# Altium schematic sheet top.SchDoc
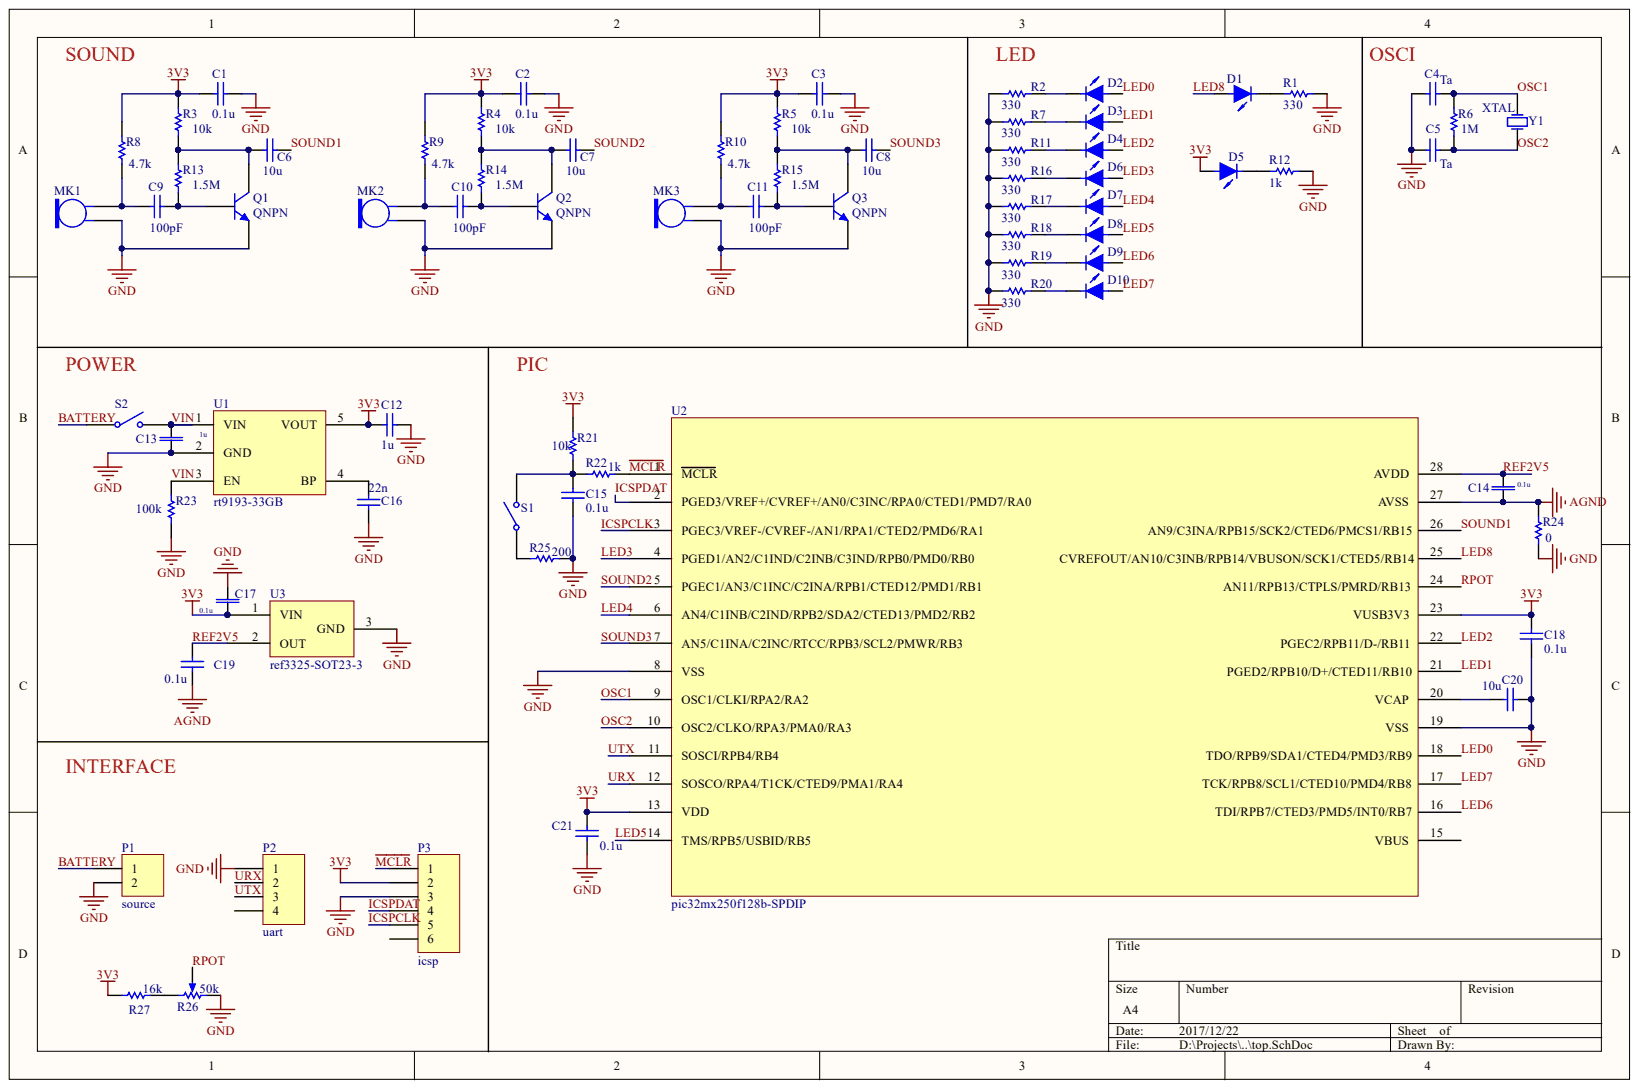
[diagram p0: top.SchDoc]

## Parts
C1  value 0.1u  [CAPC1608N]  (hidden comment: Cap)
C2  value 0.1u  [CAPC1608N]  (hidden comment: Cap)
C3  value 0.1u  [CAPC1608N]  (hidden comment: Cap)
C4  Ta  [CAPC1608N]
C5  Ta  [CAPC1608N]
C6  value 10u  [CAPC1608N]  (hidden comment: Cap)
C7  value 10u  [CAPC1608N]  (hidden comment: Cap)
C8  value 10u  [CAPC1608N]  (hidden comment: Cap)
C9  value 100pF  [CAPC1608N]  (hidden comment: Cap)
C10  value 100pF  [CAPC1608N]  (hidden comment: Cap)
C11  value 100pF  [CAPC1608N]  (hidden comment: Cap)
C12  value 1u  [CAPC1608N]  (hidden comment: Cap)
C13  value 1u  [CAPC1608N]  (hidden comment: Cap)
C14  value 0.1u  [CAPC1608N]  (hidden comment: Cap)
C15  value 0.1u  [CAPC1608N]  (hidden comment: Cap)
C16  value 22n  [CAPC1608N]  (hidden comment: Cap)
C17  value 0.1u  [CAPC1608N]  (hidden comment: Cap)
C18  value 0.1u  [CAPC1608N]  (hidden comment: Cap)
C19  value 0.1u  [CAPC1608N]  (hidden comment: Cap)
C20  Cap  [CAPC1608N]  (value not drawn)
C21  value 0.1u  [CAPC1608N]  (hidden comment: Cap)
D1  LED2  [CD1608-0603]  (value not drawn)
D2  LED2  [CD1608-0603]  (value not drawn)
D3  LED2  [CD1608-0603]
D4  LED2  [CD1608-0603]
D5  LED2  [CD1608-0603]  (value not drawn)
D6  LED2  [CD1608-0603]
D7  LED2  [CD1608-0603]  (value not drawn)
D8  LED2  [CD1608-0603]  (value not drawn)
D9  LED2  [CD1608-0603]  (value not drawn)
D10  LED2  [CD1608-0603]  (value not drawn)
MK1  Mic2  [MK]  (value not drawn)
MK2  Mic2  [MK]  (value not drawn)
MK3  Mic2  [MK]  (value not drawn)
P1  source  [HDR1X2]
P2  uart  [HDR1X4]
P3  icsp  [HDR1X6]
Q1  QNPN  [SOT-23B_N]
Q2  QNPN  [SOT-23B_N]
Q3  QNPN  [SOT-23B_N]
R1  value 330  [RESC1608N]  (hidden comment: Res1)
R2  value 330  [RESC1608N]  (hidden comment: Res1)
R3  value 10k  [RESC1608N]  (hidden comment: Res1)
R4  value 10k  [RESC1608N]  (hidden comment: Res1)
R5  value 10k  [RESC1608N]  (hidden comment: Res1)
R6  value 1M  [RESC1608N]  (hidden comment: Res1)
R7  value 330  [RESC1608N]  (hidden comment: Res1)
R8  value 4.7k  [RESC1608N]  (hidden comment: Res1)
R9  value 4.7k  [RESC1608N]  (hidden comment: Res1)
R10  value 4.7k  [RESC1608N]  (hidden comment: Res1)
R11  value 330  [RESC1608N]  (hidden comment: Res1)
R12  value 1k  [RESC1608N]  (hidden comment: Res1)
R13  value 1.5M  [RESC1608N]  (hidden comment: Res1)
R14  value 1.5M  [RESC1608N]  (hidden comment: Res1)
R15  value 1.5M  [RESC1608N]  (hidden comment: Res1)
R16  value 330  [RESC1608N]  (hidden comment: Res1)
R17  value 330  [RESC1608N]  (hidden comment: Res1)
R18  value 330  [RESC1608N]  (hidden comment: Res1)
R19  value 330  [RESC1608N]  (hidden comment: Res1)
R20  value 330  [RESC1608N]  (hidden comment: Res1)
R21  value 10k  [RESC1608N]  (hidden comment: Res1)
R22  value 1k  [RESC1608N]  (hidden comment: Res1)
R23  value 100k  [RESC1608N]  (hidden comment: Res1)
R24  value 0  [RESC1608N]  (hidden comment: Res1)
R25  value 200  [RESC1608N]  (hidden comment: Res1)
R26  value 50k  [RPOT]  (hidden comment: RPot)
R27  value 16k  [RESC1608N]  (hidden comment: Res1)
S1  SW-SPST  [sw]  (value not drawn)
S2  SW-SPST  [PIN3]  (value not drawn)
U1  rt9193-33GB  [SOT23-5_N]
U2  pic32mx250f128b-SPDIP  [DIP-28]
U3  ref3325-SOT23-3  [SOT23-3]
Y1  XTAL  [XTAL]

## Nets
3V3: C1.2 C2.2 C3.2 C12.2 C17.2 C18.1 C21.1 D5.1 P3.2 R3.2 R4.2 R5.2 R8.1 R9.1 R10.1 R21.2 R27.2 U1.5(VOUT) U2.13(VDD) U2.23(VUSB3V3) U3.1
AGND: C14.2 C19.1 R24.1 U2.27(AVSS)
GND: C1.1 C2.1 C3.1 C4.2 C5.2 C12.1 C13.2 C15.2 C16.1 C17.1 C18.2 C20.1 C21.2 MK1.1 MK2.1 MK3.1 P1.2 P2.1 P3.3 Q1.3 Q2.3 Q3.3 R1.1 R2.1 R7.1 R11.1 R12.1 R16.1 R17.1 R18.1 R19.1 R20.1 R23.1 R24.2 R25.1 R26.1 U1.2(GND) U2.8(VSS) U2.19(VSS) U3.3
BATTERY: P1.1 S2.1
ICSPCLK: P3.5 U2.3(PGEC3/VREF-/CVREF-/AN1/RPA1/CTED2/PMD6/RA1)
ICSPDAT: P3.4 U2.2(PGED3/VREF+/CVREF+/AN0/C3INC/RPA0/CTED1/PMD7/RA0)
LED0: D2.1 U2.18(TDO/RPB9/SDA1/CTED4/PMD3/RB9)
LED1: D3.1 U2.21(PGED2/RPB10/D+/CTED11/RB10)
LED2: D4.1 U2.22(PGEC2/RPB11/D-/RB11)
LED3: D6.1 U2.4(PGED1/AN2/C1IND/C2INB/C3IND/RPB0/PMD0/RB0)
LED4: D7.1 U2.6(AN4/C1INB/C2IND/RPB2/SDA2/CTED13/PMD2/RB2)
LED5: D8.1 U2.14(TMS/RPB5/USBID/RB5)
LED6: D9.1 U2.16(TDI/RPB7/CTED3/PMD5/INT0/RB7)
LED7: D10.1 U2.17(TCK/RPB8/SCL1/CTED10/PMD4/RB8)
LED8: D1.1 U2.25(CVREFOUT/AN10/C3INB/RPB14/VBUSON/SCK1/CTED5/RB14)
~{MCLR}: P3.1 R22.1 U2.1(MCLR)
OSC1: C4.1 R6.1 U2.9(OSC1/CLKI/RPA2/RA2) Y1.2
OSC2: C5.1 R6.2 U2.10(OSC2/CLKO/RPA3/PMA0/RA3) Y1.1
REF2V5: C14.1 C19.2 U2.28(AVDD) U3.2
RPOT: R26.3 U2.24(AN11/RPB13/CTPLS/PMRD/RB13)
SOUND1: C6.2 U2.26(AN9/C3INA/RPB15/SCK2/CTED6/PMCS1/RB15)
SOUND2: C7.2 U2.5(PGEC1/AN3/C1INC/C2INA/RPB1/CTED12/PMD1/RB1)
SOUND3: C8.2 U2.7(AN5/C1INA/C2INC/RTCC/RPB3/SCL2/PMWR/RB3)
URX: P2.2 U2.12(SOSCO/RPA4/T1CK/CTED9/PMA1/RA4)
UTX: P2.3 U2.11(SOSCI/RPB4/RB4)
VIN: C13.1 R23.2 S2.2 U1.1(VIN) U1.3(EN)
NetC6_1: C6.1 Q1.1 R3.1 R13.1
NetC7_1: C7.1 Q2.1 R4.1 R14.1
NetC8_1: C8.1 Q3.1 R5.1 R15.1
NetC9_1: C9.1 MK1.2 R8.2
NetC9_2: C9.2 Q1.2 R13.2
NetC10_1: C10.1 MK2.2 R9.2
NetC10_2: C10.2 Q2.2 R14.2
NetC11_1: C11.1 MK3.2 R10.2
NetC11_2: C11.2 Q3.2 R15.2
NetC15_1: C15.1 R21.1 R22.2 S1.2
NetC16_2: C16.2 U1.4(BP)
NetC20_2: C20.2 U2.20(VCAP)
NetD1_2: D1.2 R1.2
NetD2_2: D2.2 R2.2
NetD3_2: D3.2 R7.2
NetD4_2: D4.2 R11.2
NetD5_2: D5.2 R12.2
NetD6_2: D6.2 R16.2
NetD7_2: D7.2 R17.2
NetD8_2: D8.2 R18.2
NetD9_2: D9.2 R19.2
NetD10_2: D10.2 R20.2
NetR25_2: R25.2 S1.1
NetR26_2: R26.2 R27.1
N00017:

Not connected: P2 4; P3 6; U2 15(VBUS)

## Library data of the parts
C1-C3 C6-C12 C14-C19 C21 = Cap: Capacitor; Component Kind: Standard; LatestRevisionDate: 17-Jul-2002; LatestRevisionNote: Re-released for DXP Platform.; Library Name: Miscellaneous Devices.IntLib; PackageReference: RAD-0.3; Published: 8-Jun-2000; Signal Integrity: Cap; Simulation: CAP; Publisher: Altium Limited
  C1-C3 C6-C12 C15 C16 C18 C19 C21: PackageDescription: Radial Cap, Thru-Hole; 2 Leads; 0.3 in Pin Spacing
C4 C5 = Cap: Capacitor; Value: 100pF; Component Kind: Standard; LatestRevisionDate: 17-Jul-2002; LatestRevisionNote: Re-released for DXP Platform.; Library Name: Miscellaneous Devices.IntLib; PackageDescription: Radial Cap, Thru-Hole; 2 Leads; 0.3 in Pin Spacing; PackageReference: RAD-0.3; Published: 8-Jun-2000; Signal Integrity: Cap; Simulation: CAP; Publisher: Altium Limited
C13 = Cap: Capacitor; Value: 1u; Component Kind: Standard; LatestRevisionDate: 17-Jul-2002; LatestRevisionNote: Re-released for DXP Platform.; Library Name: Miscellaneous Devices.IntLib; PackageReference: RAD-0.3; Published: 8-Jun-2000; Signal Integrity: Cap; Simulation: CAP; Publisher: Altium Limited
C20 = Cap: Capacitor; Value: 10u; Component Kind: Standard; LatestRevisionDate: 17-Jul-2002; LatestRevisionNote: Re-released for DXP Platform.; Library Name: Miscellaneous Devices.IntLib; PackageDescription: Radial Cap, Thru-Hole; 2 Leads; 0.3 in Pin Spacing; PackageReference: RAD-0.3; Published: 8-Jun-2000; Signal Integrity: Cap; Simulation: CAP; Publisher: Altium Limited
D1-D10 = LED2: Typical RED, GREEN, YELLOW, AMBER GaAs LED; Component Kind: Standard; LatestRevisionDate: 17-Jul-2002; LatestRevisionNote: Re-released for DXP Platform.; Library Name: Miscellaneous Devices.IntLib; PackageReference: 3.2X1.6X1.1; PackageVersion: Feb-2002; Published: 8-Jun-2000; Simulation: LED2; Publisher: Altium Limited
MK1-MK3 = Mic2: Microphone; Component Kind: Standard; LatestRevisionDate: 17-Jul-2002; LatestRevisionNote: Re-released for DXP Platform.; Library Name: Miscellaneous Devices.IntLib; PackageReference: PIN2; Published: 24-Mar-1999; Publisher: Altium Limited
P1 = Header 2: Header, 2-Pin; Component Kind: Standard; LatestRevisionDate: 17-Jul-2002; LatestRevisionNote: Re-released for DXP Platform.; Library Name: Miscellaneous Connectors.IntLib; Signal Integrity: Connector; Publisher: Altium Limited
P2 = Header 4: Header, 4-Pin; Component Kind: Standard; LatestRevisionDate: 17-Jul-2002; LatestRevisionNote: Re-released for DXP Platform.; Library Name: Miscellaneous Connectors.IntLib; Signal Integrity: Connector; Publisher: Altium Limited
P3 = Header 6: Header, 6-Pin; Component Kind: Standard; LatestRevisionDate: 17-Jul-2002; LatestRevisionNote: Re-released for DXP Platform.; Library Name: Miscellaneous Connectors.IntLib; Signal Integrity: Connector; Publisher: Altium Limited
Q1-Q3 = QNPN: NPN Bipolar Transistor; Component Kind: Standard; LatestRevisionDate: 29-May-2009; LatestRevisionNote: IPC-7351 Footprint Added.; Library Name: Miscellaneous Devices.IntLib; PackageDescription: 3-Pin SOT-23 Package 0.95 mm Pitch, 2.4 mm Lead Span; PackageReference: SOT-23B; PackageVersion: Aug-1999; Published: 8-Jun-2000; Signal Integrity: NPN; Simulation: QNPN; Publisher: Altium Limited
R1-R12 R16-R25 R27 = Res1: Resistor; Component Kind: Standard; LatestRevisionDate: 17-Jul-2002; LatestRevisionNote: Re-released for DXP Platform.; Library Name: Miscellaneous Devices.IntLib; PackageDescription: Axial Device, Thru-Hole; 2 Leads; 0.3 in Pin Spacing; PackageReference: AXIAL-0.3; Published: 8-Jun-2000; Signal Integrity: Res; Simulation: RESISTOR; Publisher: Altium Limited
R13-R15 = Res1: Resistor; Value: 1.5M; Component Kind: Standard; LatestRevisionDate: 17-Jul-2002; LatestRevisionNote: Re-released for DXP Platform.; Library Name: Miscellaneous Devices.IntLib; PackageDescription: Axial Device, Thru-Hole; 2 Leads; 0.3 in Pin Spacing; PackageReference: AXIAL-0.3; Published: 8-Jun-2000; Signal Integrity: Res; Simulation: RESISTOR; Publisher: Altium Limited
R26 = RPot: Potentiometer; Set Position: 0.5; Sim Note: Enter the Resistance value and the set point into parameter fields.; Component Kind: Standard; LatestRevisionDate: 17-Jul-2002; LatestRevisionNote: Re-released for DXP Platform.; Library Name: Miscellaneous Devices.IntLib; PackageDescription: Variable Resistor, Thru-Hole; 3 Leads; PackageReference: VR5; Published: 8-Jun-2000; Simulation: POT; Publisher: Altium Limited
S1 S2 = SW-SPST: Single-Pole, Single-Throw Switch; Component Kind: Standard; LatestRevisionDate: 17-Jul-2002; LatestRevisionNote: Re-released for DXP Platform.; Library Name: Miscellaneous Devices.IntLib; PackageDescription: Switch; 2 Leads; PackageReference: SPST-2; Published: 24-Mar-1999; Publisher: Altium Limited
U1 = rt9193: Component Kind: Standard; Description: rt9193; Library Name: rt9193.SchLib
U2 = pic32mx250f128b: Component Kind: Standard; Library Name: Schlib1.SchLib
U3 = ref33xx: Component Kind: Standard; Description: ref33xx; Library Name: ref33xx.SchLib
Y1 = XTAL: Crystal Oscillator; Component Kind: Standard; LatestRevisionDate: 17-Jul-2002; LatestRevisionNote: Re-released for DXP Platform.; Library Name: Miscellaneous Devices.IntLib; PackageReference: R38; Published: 8-Jun-2000; Simulation: XTAL; Publisher: Altium Limited
